# Altium schematic sheet MCU Circuit.SchDoc
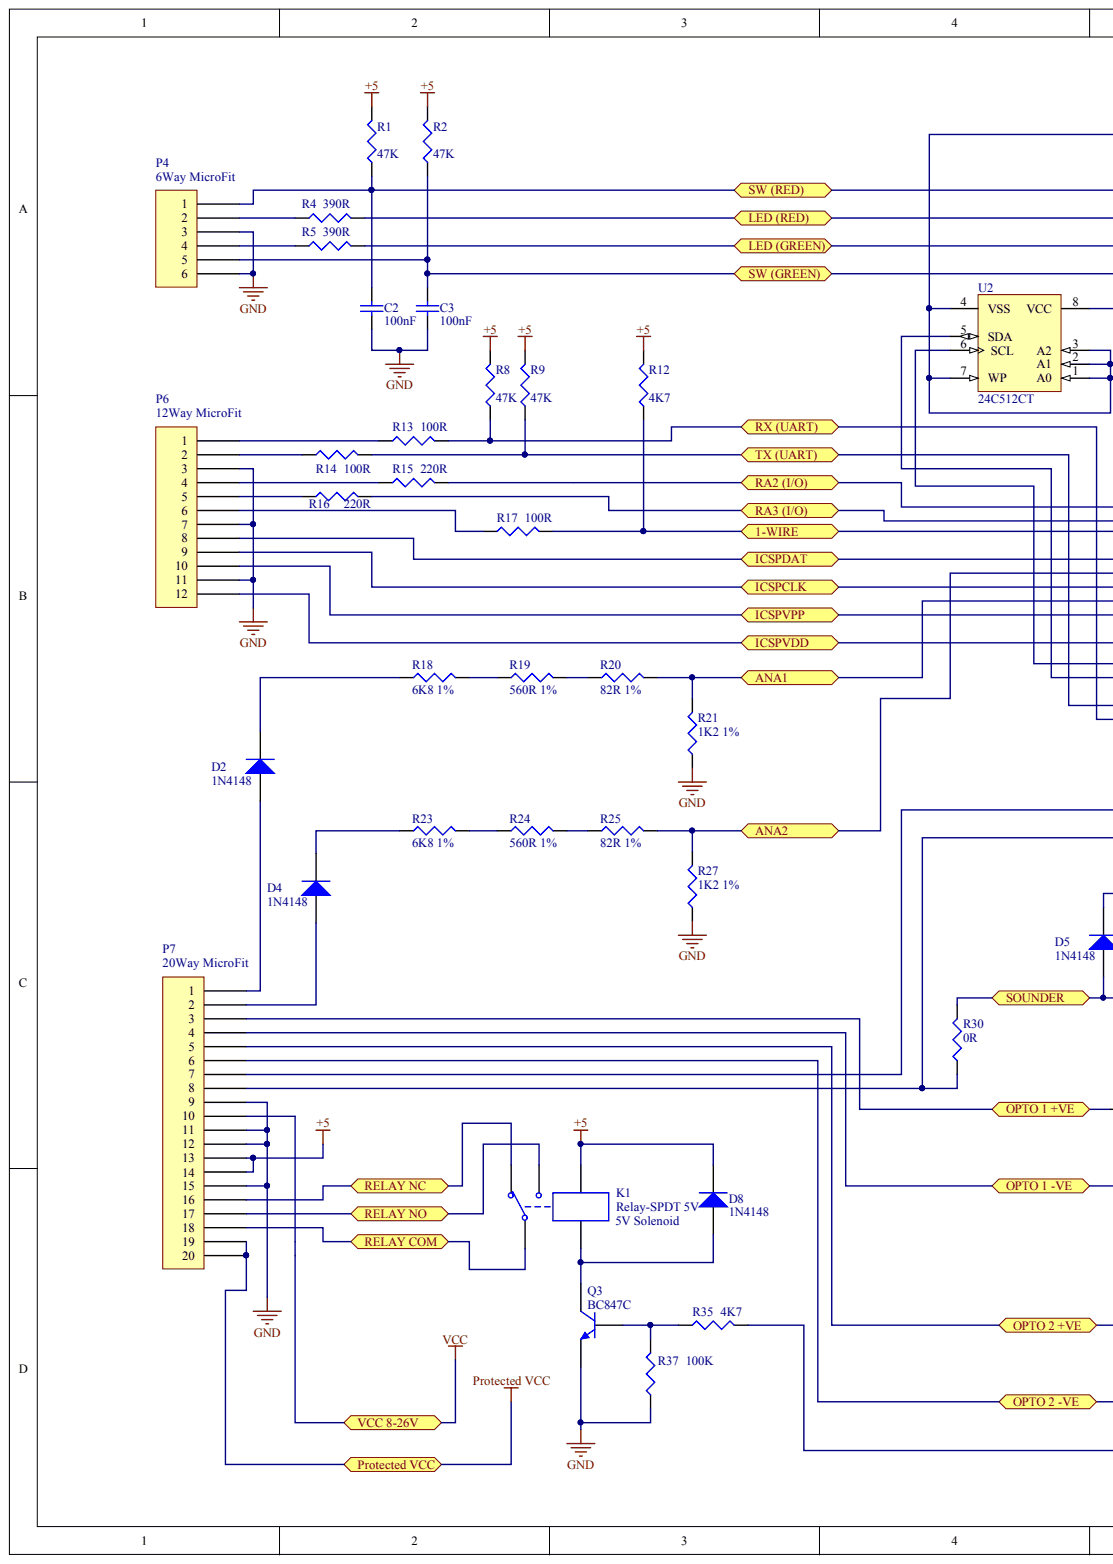
[diagram p0: MCU Circuit.SchDoc, left]
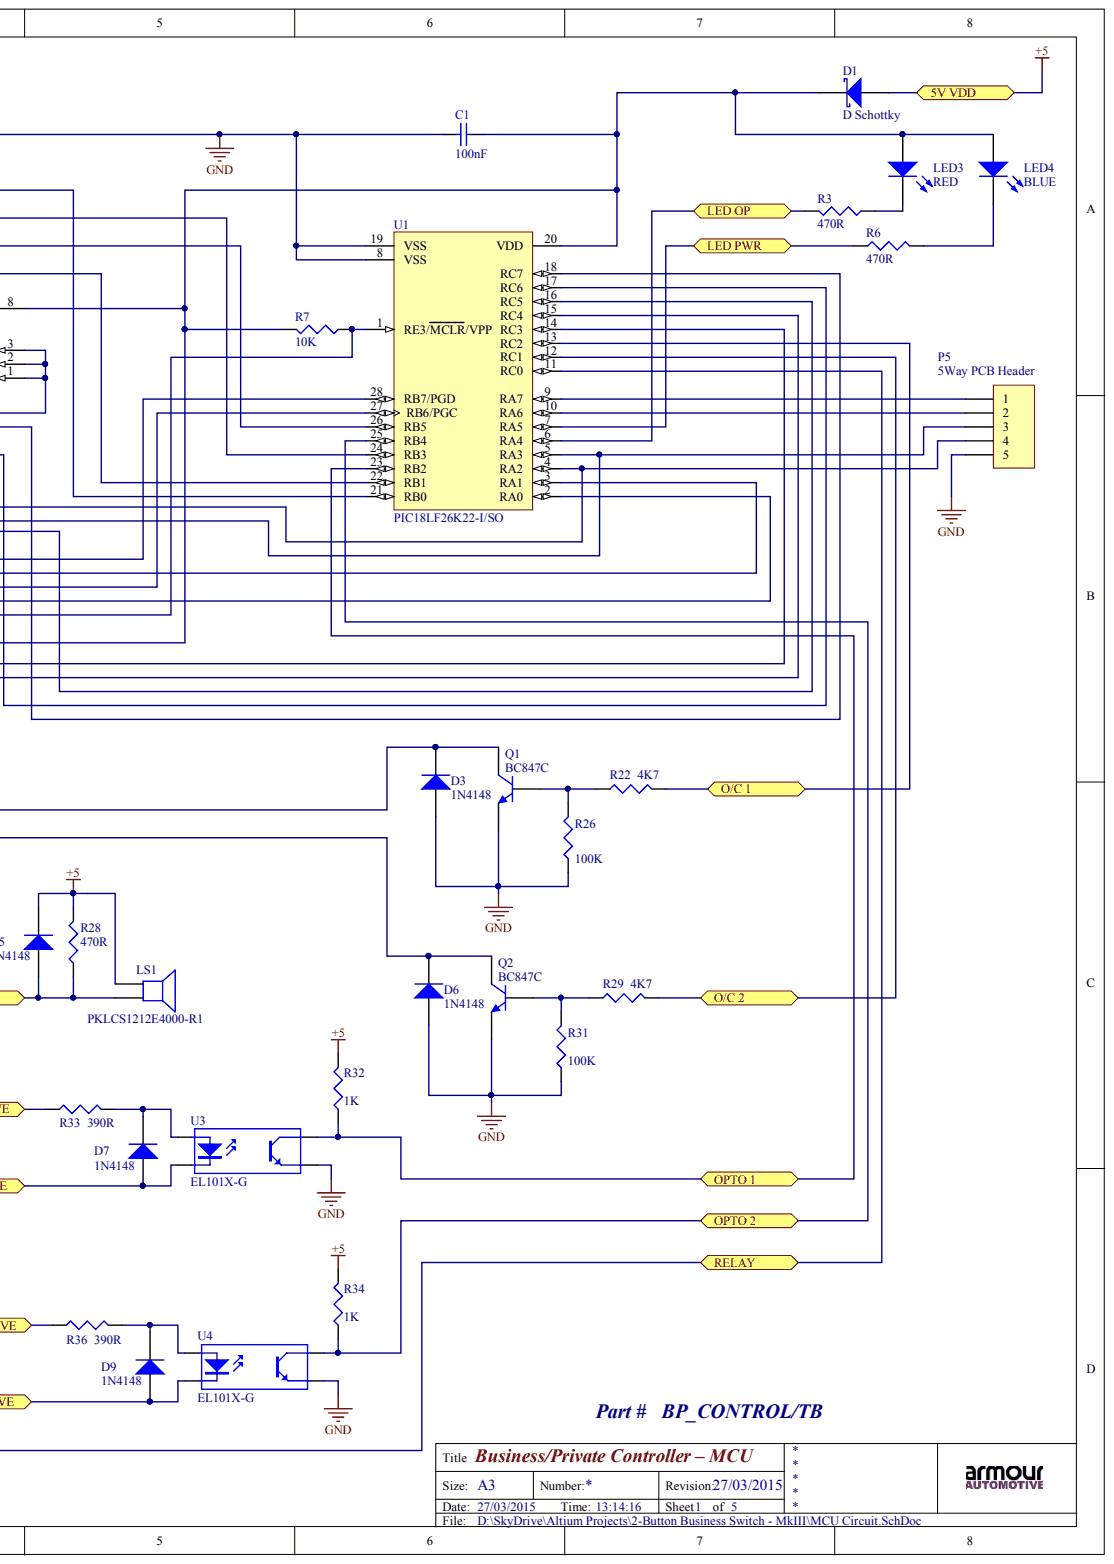
[diagram p1: MCU Circuit.SchDoc, right]

## Parts
C1  100n  value 100nF  [1608[0603]]
C2  100n  value 100nF  [1608[0603]]
C3  100n  value 100nF  [1608[0603]]
D1  D Schottky  [SMB]
D2  1N4148  [SMC]
D3  1N4148  [SMC]
D4  1N4148  [SMC]
D5  1N4148  [SMC]
D6  1N4148  [SMC]
D7  1N4148  [SMC]
D8  1N4148  [SMC]
D9  1N4148  [SMC]
K1  Relay-SPDT 5V  [MODULE5B]
LED3  RED  [3.5X2.8X1.9]
LED4  BLUE  [3.5X2.8X1.9]
LS1  PKLCS1212E4000-R1  [Murata Sounder]
P4  6Way MicroFit
P5  5Way PCB Header  [HDR1X5]
P6  12Way MicroFit
P7  20Way MicroFit
Q1  BC847C  [SOT23_N]
Q2  BC847C  [SOT23_N]
Q3  BC847C  [SOT23_N]
R1  47K  [J1-0603]
R2  47K  [J1-0603]
R3  470R  [J1-0603]
R4  390R  [J1-0603]
R5  390R  [J1-0603]
R6  470R  [J1-0603]
R7  10K  [J1-0603]
R8  47K  [J1-0603]
R9  47K  [J1-0603]
R12  4K7  [J1-0603]
R13  100R  [J1-0603]
R14  100R  [J1-0603]
R15  220R  [J1-0603]
R16  220R  [J1-0603]
R17  100R  [J1-0603]
R18  6K8 1%  value 6K8  [J1-0603]
R19  560R 1%  value 560R  [J1-0603]
R20  82R 1%  value 82R  [J1-0603]
R21  1K2 1%  value 1K2  [J1-0603]
R22  4K7  [J1-0603]
R23  6K8 1%  value 6K8  [J1-0603]
R24  560R 1%  value 560R  [J1-0603]
R25  82R 1%  value 82R  [J1-0603]
R26  100K  [J1-0603]
R27  1K2 1%  value 1K2  [J1-0603]
R28  470R  [J1-0603]
R29  4K7  [J1-0603]
R30  0R  [J1-0603]
R31  100K  [J1-0603]
R32  1K  [J1-0603]
R33  390R  [J1-0603]
R34  1K  [J1-0603]
R35  4K7  [J1-0603]
R36  390R  [J1-0603]
R37  100K  [J1-0603]
U1  PIC18LF26K22-I/SO  [MCHP-SOIC-SO28_N]
U2  24C512CT  [SOIC-SN8_N]
U3  EL101X-G  [EL101]
U4  EL101X-G  [EL101]

## Ports
1-WIRE, 5V VDD, ANA1, ANA2, ICSPCLK, ICSPDAT, ICSPVDD, ICSPVPP, LED (GREEN), LED (RED), LED OP, LED PWR, O/C 1, O/C 2, OPTO 1, OPTO 1 +VE, OPTO 1 -VE, OPTO 2, OPTO 2 +VE, OPTO 2 -VE, PROTECTED VCC, RA2 (I/O), RA3 (I/O), RELAY, RELAY COM, RELAY NC, RELAY NO, RX (UART), SOUNDER, SW (GREEN), SW (RED), TX (UART), VCC 8-26V

## Nets
GND: C1.2 C2.2 C3.2 D3.1 D6.1 P4.3 P4.6 P5.5 P6.3 P6.7 P6.11 P7.9 P7.11 P7.12 P7.15 Q1.2 Q2.2 Q3.2 R21.1 R26.1 R27.1 R31.1 R37.1 U1.8(VSS) U1.19(VSS) U2.1(A0) U2.2(A1) U2.3(A2) U2.4(VSS) U2.7(WP) U3.3 U4.3
PROTECTED VCC: P7.19 P7.20 ; port PROTECTED VCC
1-WIRE: R12.1 R17.2 U1.16(RC5) ; port 1-WIRE
+5: D1.1 D5.2 D8.2 K1.5 LS1.1 P7.13 P7.14 R1.2 R2.2 R8.2 R9.2 R12.2 R28.2 R32.2 R34.2 ; port 5V VDD
ANA1: R20.2 R21.2 U1.2(RA0) ; port ANA1
ANA2: R25.2 R27.2 U1.3(RA1) ; port ANA2
ICSPCLK: P6.9 U1.27(RB6/PGC) ; port ICSPCLK
ICSPDAT: P6.8 U1.28(RB7/PGD) ; port ICSPDAT
ICSPVDD: C1.1 D1.2 LED3.1 LED4.1 P6.12 R7.2 U1.20(VDD) U2.8(VCC) ; port ICSPVDD
ICSPVPP: P6.10 R7.1 U1.1(RE3/MCLR/VPP) ; port ICSPVPP
LED (GREEN): R5.2 U1.26(RB5) ; port LED (GREEN)
LED (RED): R4.2 U1.24(RB3) ; port LED (RED)
LED OP: R3.2 U1.6(RA4) ; port LED OP
LED PWR: R6.1 U1.7(RA5) ; port LED PWR
O/C 1: R22.2 U1.13(RC2) ; port O/C 1
O/C 2: R29.2 U1.12(RC1) ; port O/C 2
OPTO 1: R32.1 U1.23(RB2) U3.4 ; port OPTO 1
OPTO 2: R34.1 U1.25(RB4) U4.4 ; port OPTO 2
RA2 (I/O): P5.4 R15.2 U1.4(RA2) ; port RA2 (I/O)
RA3 (I/O): P5.3 R16.2 U1.5(RA3) ; port RA3 (I/O)
RELAY: R35.2 U1.11(RC0) ; port RELAY
RELAY COM: K1.1 P7.18 ; port RELAY COM
RELAY NC: K1.2 P7.16 ; port RELAY NC
RELAY NO: K1.3 P7.17 ; port RELAY NO
RX (UART): R8.1 R13.2 U1.18(RC7) ; port RX (UART)
SOUNDER: D5.1 LS1.2 R28.1 R30.2 ; port SOUNDER
SW (GREEN): C3.1 P4.5 R2.1 U1.22(RB1) ; port SW (GREEN)
SW (RED): C2.1 P4.1 R1.1 U1.21(RB0) ; port SW (RED)
TX (UART): R9.1 R14.2 U1.17(RC6) ; port TX (UART)
VCC: P7.10 ; port VCC 8-26V
NetD2_1: D2.1 P7.1
NetD2_2: D2.2 R18.1
NetD3_2: D3.2 P7.7 Q1.3
NetD4_1: D4.1 P7.2
NetD4_2: D4.2 R23.1
NetD6_2: D6.2 P7.8 Q2.3 R30.1
NetD7_1: D7.1 P7.4 U3.2
NetD7_2: D7.2 R33.2 U3.1
NetD8_1: D8.1 K1.4 Q3.3
NetD9_1: D9.1 P7.6 U4.2
NetD9_2: D9.2 R36.2 U4.1
NetLED3_2: LED3.2 R3.1
NetLED4_2: LED4.2 R6.2
NetP4_2: P4.2 R4.1
NetP4_4: P4.4 R5.1
NetP5_1: P5.1 U1.9(RA7)
NetP5_2: P5.2 U1.10(RA6)
NetP6_1: P6.1 R13.1
NetP6_2: P6.2 R14.1
NetP6_4: P6.4 R15.1
NetP6_5: P6.5 R16.1
NetP6_6: P6.6 R17.1
NetP7_3: P7.3 R33.1
NetP7_5: P7.5 R36.1
NetQ1_1: Q1.1 R22.1 R26.2
NetQ2_1: Q2.1 R29.1 R31.2
NetQ3_1: Q3.1 R35.1 R37.2
NetR18_2: R18.2 R19.1
NetR19_2: R19.2 R20.1
NetR23_2: R23.2 R24.1
NetR24_2: R24.2 R25.1
NetU1_14: U1.14(RC3) U2.6(SCL)
NetU1_15: U1.15(RC4) U2.5(SDA)

## Library data of the parts
C1-C3 = Cap Semi: Capacitor (Semiconductor SIM Model); Component Kind: Standard; LatestRevisionDate: 29-May-2009; LatestRevisionNote: IPC-7351 Footprint Added.; Library Name: Miscellaneous Devices.IntLib; PackageDescription: Chip Capacitor; PackageReference: C1206; Published: 8-Jun-2000; Signal Integrity: Cap Semi; Simulation: CAP; Publisher: Altium Limited
D1 = D Schottky: Schottky Diode; Code_JEDEC: DO-214-AA; Component Kind: Standard; LatestRevisionDate: 17-Jul-2002; LatestRevisionNote: Re-released for DXP Platform.; Library Name: Miscellaneous Devices.IntLib; PackageDescription: DO-214-AA/SMB; 2 C-Bend Leads; Body 5.3 x 3.6 mm, inc. leads (LxW); PackageReference: SMB; Published: 8-Jun-2000; Signal Integrity: D Schottky; Simulation: SKYDIODE; Publisher: Altium Limited
D2-D9 = Diode: Default Diode; Code_JEDEC: DO-214-AB; Component Kind: Standard; LatestRevisionDate: 17-Jul-2002; LatestRevisionNote: Re-released for DXP Platform.; Library Name: Miscellaneous Devices.IntLib; PackageDescription: DO-214-AB/SMC; 2 C-Bend Leads; Body 7.9 x 5.9 mm, inc. leads (LxW); PackageReference: SMC; PackageVersion: Sep-1996; Published: 8-Jun-2000; Signal Integrity: Diode; Simulation: DIODE; Publisher: Altium Limited
K1 = Relay-SPDT: Single-Pole Dual-Throw Relay; Component Kind: Standard; LatestRevisionDate: 17-Jul-2002; LatestRevisionNote: Re-released for DXP Platform.; Library Name: Miscellaneous Devices.IntLib; PackageReference: MODULE5B; Published: 24-Mar-1999; Simulation: SPDTRELAY; Publisher: Altium Limited
LED3 = LED3: Typical RED GaAs LED; Component Kind: Standard; DatasheetVersion: 16-Apr-2002; LatestRevisionDate: 17-Dec-2003; LatestRevisionNote: PCB Footprint replaced.; Library Name: Miscellaneous Devices.IntLib; PackageDescription: SM LED; 2 C-Bend Leads; Body 3.5 x 2.8 x 1.9 mm, inc leads (LxWxH typ); PackageReference: 3.5X2.8X1.9; PackageVersion: 16-Apr-2002; Published: 8-Jun-2000; Signal Integrity: LED; Simulation: LED3; Publisher: Altium Limited
LED4 = LED3: Typical BLUE SiC LED; Component Kind: Standard; DatasheetVersion: 16-Apr-2002; LatestRevisionDate: 17-Dec-2003; LatestRevisionNote: PCB Footprint replaced.; Library Name: Miscellaneous Devices.IntLib; PackageDescription: SM LED; 2 C-Bend Leads; Body 3.5 x 2.8 x 1.9 mm, inc leads (LxWxH typ); PackageReference: 3.5X2.8X1.9; PackageVersion: 16-Apr-2002; Published: 8-Jun-2000; Signal Integrity: LED; Simulation: LED3; Publisher: Altium Limited
LS1 = PKLCS1212E4000-R1: Piezo Sounder - 4 KHz; Component Kind: Standard; LatestRevisionDate: 17-Jul-2002; LatestRevisionNote: Re-released for DXP Platform.; Library Name: AA User Library.IntLib; PackageReference: PIN2; Published: 24-Mar-1999; Publisher: Altium Limited
P4 = M2-653LF 6Way Header: Component Kind: Standard; Library Name: AA User Library.IntLib
P5 = Header 5: Header, 5-Pin; Component Kind: Standard; LatestRevisionDate: 17-Jul-2002; LatestRevisionNote: Re-released for DXP Platform.; Library Name: Miscellaneous Connectors.IntLib; Signal Integrity: Connector; Publisher: Altium Limited
P6 = M2-653LF 12Way Header: Component Kind: Standard; Library Name: AA User Library.IntLib
P7 = M2-653LF 20Way Header: Component Kind: Standard; Library Name: AA User Library.IntLib
Q1-Q3 = BC847C: NPN General-purpose Transistor; Component Kind: Standard; ComponentLink1Description: Manufacturer Link; ComponentLink1URL: http://www.semiconductors.philips.com/; ComponentLink2Description: Datasheet; ComponentLink2URL: http://www.semiconductors.philips.com/acrobat/datasheets/BC846_BC847_BC848_5.pdf; DatasheetVersion: 4-Feb-2002; LatestRevisionDate: 12-Dec-2006; LatestRevisionNote: IPC-7351 Footprint Added.; Library Name: Philips Discrete BJT - Low Power.IntLib; PackageDescription: 3-Pin SOT-23 Package 0.95 mm Pitch, 2.3 mm Lead Span; PackageReference: SOT23; PackageVersion: May-1999; Published: 17-Sep-2003; Signal Integrity: BC847C; Simulation: QBC847C; Publisher: Altium Limited
R1-R9 R12-R37 = Res3: Resistor; Component Kind: Standard; LatestRevisionDate: 29-May-2009; LatestRevisionNote: IPC-7351 Footprint Added.; Library Name: Miscellaneous Devices.IntLib; PackageDescription: Chip Resistor; PackageReference: J1-0603; Published: 8-Jun-2000; Signal Integrity: Res; Simulation: RESISTOR; Publisher: Altium Limited
  R1 R2 R8 R9: Value: 47K
  R3 R6 R28: Value: 470R
  R4 R5 R33 R36: Value: 390R
  R7: Value: 10K
  R12 R22 R29 R35: Value: 4K7
  R13 R14 R17: Value: 100R
  R15 R16: Value: 220R
  R26 R31 R37: Value: 100K
  R30: Value: 0R
  R32 R34: Value: 1K
U1 = PIC18LF26K22-I/SO: Low-Power, High-Performance Microcontroller with XLP Technology, 64 MHz, 24 I/O, -40 to 85 degC, 28-pin SOIC (SO28), Tube; Manufacturer: Microchip; # of A/D Channels: 17; Digital Communication: 0 -UART, 2 -A/E/USART, 2 -MSSP(SPI/I2C); EEPROM Data Memory (bytes): 1024; I/O Pins: 24; Internal Oscillator: 16 MHz, 31 kHz; Max. CPU Speed MHz: 64; Memory Type: Flash; Operation Voltage Range: 1.8V - 3.6V; Program Memory KWords: 32; RAM: 3896; Timers: 3 - 8-bit, 4 - 16-bit; Component Kind: Standard; ComponentLink1Description: Datasheet; ComponentLink1URL: http://ww1.microchip.com/downloads/en/DeviceDoc/41412F.pdf; ComponentLink2Description: Package Specification; ComponentLink2URL: http://www.microchip.com/stellent/groups/techpub_sg/documents/packagingspec/en012702.pdf; ComponentLink3Description: URL Link1; ComponentLink3URL: Product URL:http://www.microchip.com/datasheet/PIC18F26K22; DatasheetVersion: Rev. F; Library Name: Microchip PIC18.IntLib; Mounting Technology: Surface Mount; PackageDescription: 28-Lead Plastic Small Outline, 17.9 x 7.5 mm Body, 1.27 mm Pitch; PackageReference: SOIC-SO28; PackageVersion: C04-052C, 12/2012; Packing: TUBE; PartNumber: PIC18LF26K22-I/SO; Program Memory KBytes: 64; Supplier 1: Digi-Key; Supplier 2: Farnell; Supplier 3: Newark; Supplier 4: Mouser; Supplier Part Number 1: PIC18LF26K22-I/SO-ND; Supplier Part Number 2: 1814982; Supplier Part Number 3: 65R6036; Supplier Part Number 4: 579-PIC18LF26K22ISO
U2 = 24C02CT-I/SN: 2Kbit, 400kHz, 5V, I2C Serial EEPROM, 8-Pin SOIC 150mil, Industrial Temperature, Tape and Reel; Code_IPC: SOIC127P600-8; Code_JEDEC: MS-012; Component Kind: Standard; ComponentLink1Description: Datasheet; ComponentLink1URL: http://ww1.microchip.com/downloads/en/DeviceDoc/21202J.pdf; ComponentLink2Description: Packaging Specification; ComponentLink2URL: http://www.microchip.com/stellent/groups/techpub_sg/documents/packagingspec/en012702.pdf; ComponentLink3Description: Microchip.com Home; ComponentLink3URL: http://www.microchip.com/; DatasheetVersion: revJ, Aug-2008; Library Name: Microchip Memory I2C Serial EEPROM.IntLib; PackageDescription: 8-Lead Plastic Small Outline (SN) - Narrow, 3.90mm Body [SOIC]; PackageReference: SOIC-SN8; PackageVersion: revBB, Aug-2009; Published: 29-Jan-2009; Supplier 1: Digi-Key; Supplier Part Number 1: 24C512CT; Publisher: Altium Limited
U3 U4 = EL101X-G: Long Creepage Opto-Isolator (4uS); Component Kind: Standard; Library Name: AA User Library.IntLib
